# Altium schematic sheet CP2104&USB_OTG.SchDoc(CP2104&USB_OTG)
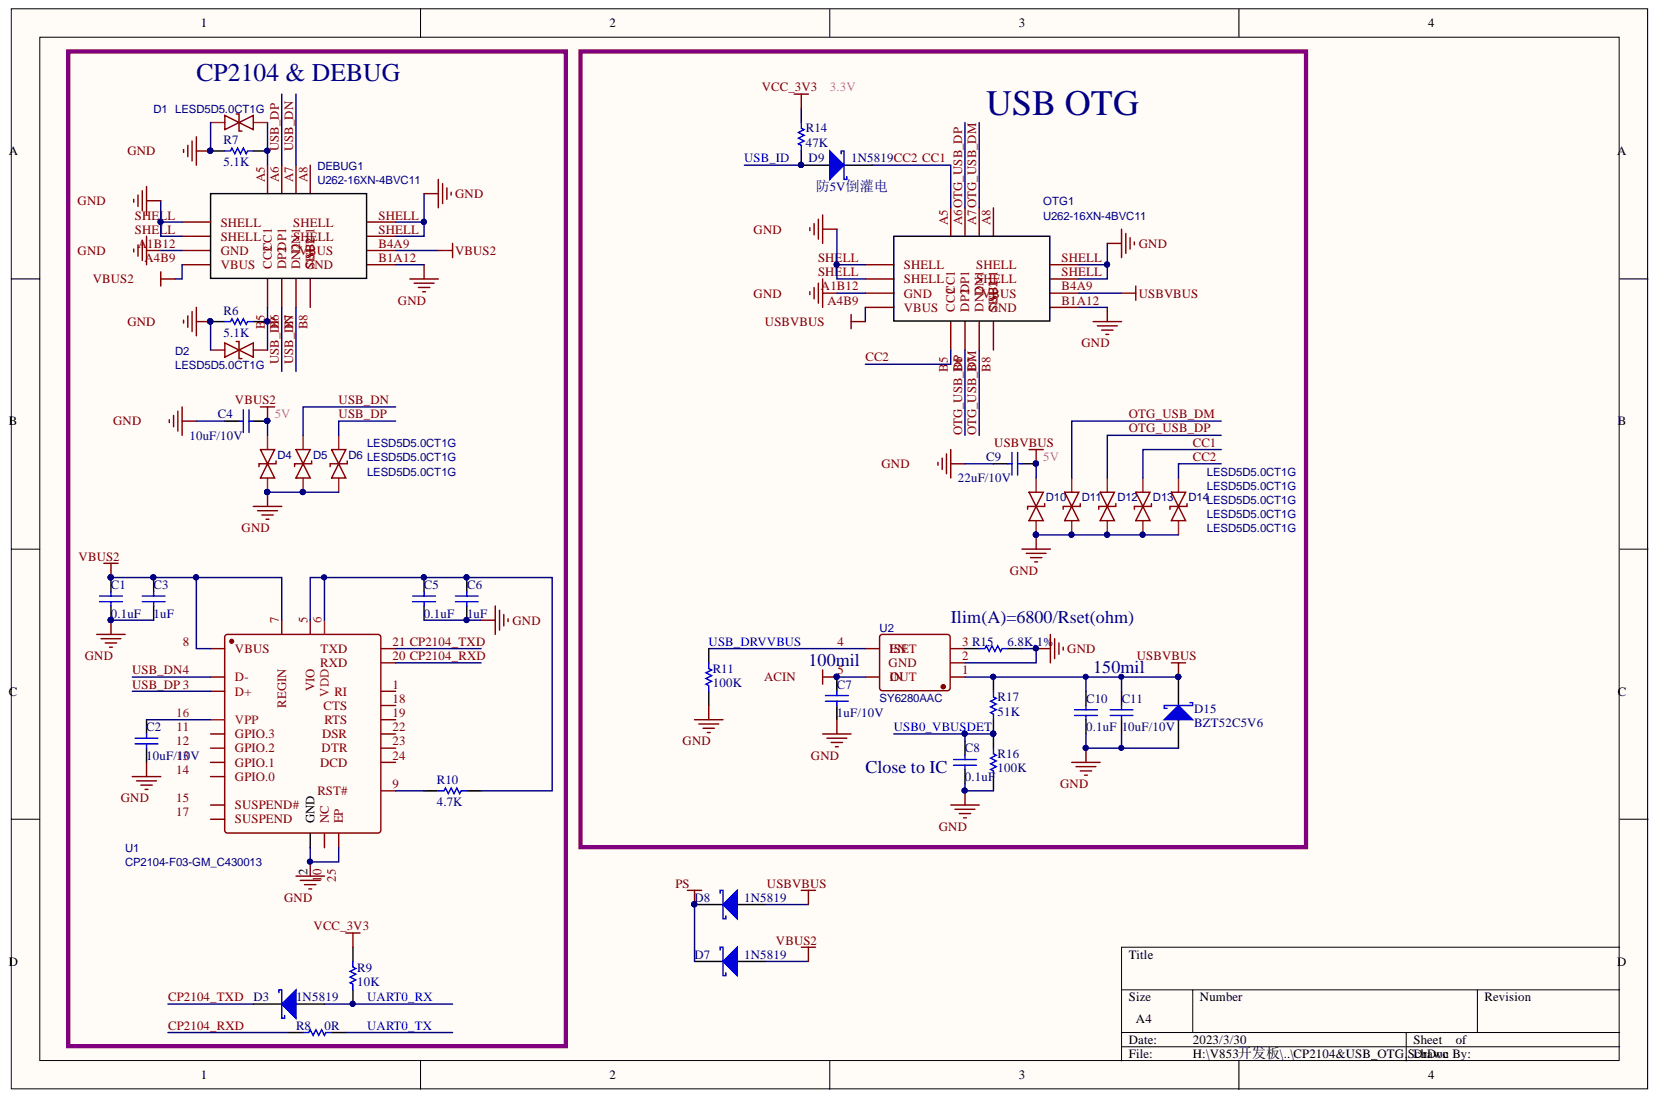
[diagram p0: CP2104&USB_OTG.SchDoc]

## Parts
C1  0.1uF  [0402]
C2  10uF/10V  [0402]
C3  1uF  [0402]
C4  10uF/10V  [0402]
C5  0.1uF  [0402]
C6  1uF  [0402]
C7  1uF/10V  [0402]
C8  0.1uF  [0402]
C9  22uF/10V  [0603]
C10  0.1uF  [0402]
C11  10uF/10V  [0402]
D1  LESD5D5.0CT1G  [SOD-523_L1.2-W0.8-LS1.6-BI]
D2  LESD5D5.0CT1G  [SOD-523_L1.2-W0.8-LS1.6-BI]
D3  1N5819  [SOD-123_L2.8-W1.8-LS3.7-RD]
D4  LESD5D5.0CT1G  [SOD-523_L1.2-W0.8-LS1.6-BI]
D5  LESD5D5.0CT1G  [SOD-523_L1.2-W0.8-LS1.6-BI]
D6  LESD5D5.0CT1G  [SOD-523_L1.2-W0.8-LS1.6-BI]
D7  1N5819  [SOD-123_L2.8-W1.8-LS3.7-RD]
D8  1N5819  [SOD-123_L2.8-W1.8-LS3.7-RD]
D9  1N5819  [SOD-123_L2.8-W1.8-LS3.7-RD]
D10  LESD5D5.0CT1G  [SOD-523_L1.2-W0.8-LS1.6-BI]
D11  LESD5D5.0CT1G  [SOD-523_L1.2-W0.8-LS1.6-BI]
D12  LESD5D5.0CT1G  [SOD-523_L1.2-W0.8-LS1.6-BI]
D13  LESD5D5.0CT1G  [SOD-523_L1.2-W0.8-LS1.6-BI]
D14  LESD5D5.0CT1G  [SOD-523_L1.2-W0.8-LS1.6-BI]
D15  BZT52C5V6  [SOD-123_L2.8-W1.8-LS3.7-RD]
DEBUG1  U262-16XN-4BVC11  [TYPEC-12PIN - duplicate]
OTG1  U262-16XN-4BVC11  [TYPEC-12PIN - duplicate]
R6  5.1K  [0402]
R7  5.1K  [0402]
R8  0R  [0402]
R9  10K  [0402]
R10  4.7K  [0402]
R11  100K  [0402]
R14  47K  [0402]
R15  6.8K,1%  [0402]
R16  100K  [0402]
R17  51K  [0402]
U1  CP2104-F03-GM_C430013  [QFN-24_L4.0-W4.0-P0.50-TL-EP2.7]
U2  SY6280AAC  [SOT-23-5_L3.0-W1.7-P0.95-LS2.8-BR]

## Nets
ACIN: C7.2 U2.5(IN)
GND: C1.2 C2.1 C3.2 C4.1 C5.2 C6.2 C7.1 C8.2 C9.1 C10.2 C11.1 D1.2 D2.2 D4.1 D5.1 D6.1 D10.1 D11.1 D12.1 D13.1 D14.1 D15.1 DEBUG1.A1B12(GND) DEBUG1.B1A12 DEBUG1.SHELL OTG1.A1B12(GND) OTG1.B1A12 OTG1.SHELL R6.2 R7.2 R11.1 R15.1 R16.1 U1.2(GND) U1.25(EP) U2.2
PS: D7.2 D8.2
USBVBUS: C9.2 C10.1 C11.2 D8.1 D10.2 D15.2 OTG1.A4B9(VBUS) OTG1.B4A9 R17.2 U2.1
VBUS2: C1.1 C3.1 C4.2 D4.2 D7.1 DEBUG1.A4B9(VBUS) DEBUG1.B4A9 U1.7 U1.8(VBUS)
VCC_3V3: R9.2 R14.2
CC1: D9.2 D13.2 D14.2 OTG1.A5 OTG1.B5(CC2)
CP2104_RXD: R8.1 U1.20
CP2104_TXD: D3.2 U1.21
OTG_USB_DM: D11.2 OTG1.A7 OTG1.B7(DN2)
OTG_USB_DP: D12.2 OTG1.A6 OTG1.B6(DP2)
UART0_RX: D3.1 R9.1
UART0_TX: R8.2
USB0_VBUSDET: C8.1 R16.2 R17.1
USB_DN: D5.2 DEBUG1.A7 DEBUG1.B7(DN2) U1.4(D-)
USB_DP: D6.2 DEBUG1.A6 DEBUG1.B6(DP2) U1.3(D+)
USB_DRVVBUS: R11.2 U2.4(ISET)
USB_ID: D9.1 R14.1
NetC2_2: C2.2 U1.16(VPP)
NetC5_1: C5.1 C6.1 R10.2 U1.5 U1.6
NetD1_1: D1.1 DEBUG1.A5 R7.1
NetD2_1: D2.1 DEBUG1.B5(CC2) R6.1
NetR10_1: R10.1 U1.9
NetR15_2: R15.2 U2.3

Not connected: DEBUG1 A8 B8(SBU2); OTG1 A8 B8(SBU2); U1 1 10(NC) 11(GPIO.3) 12(GPIO.2) 13(GPIO.1) 14(GPIO.0) 15(SUSPEND#) 17(SUSPEND) 18 19 22 23 24

## Library data of the parts
C1-C11 = Cap: Capacitor; PartNumber: CAP100NF; Component Kind: Standard; LatestRevisionDate: 17-Jul-2002; LatestRevisionNote: Re-released for DXP Platform.; Library Name: Miscellaneous Devices.IntLib; PackageReference: 0402; Published: 8-Jun-2000; Signal Integrity: Cap; Simulation: CAP; Publisher: Altium Limited
  C1 C5 C8 C10: Value: 0.1uF
  C2 C4 C11: Value: 10uF/10V
  C3 C6: Value: 1uF
  C7: Value: 1uF/10V
  C9: Value: 22uF/10V
D1 D2 D4-D6 D10-D14 = LESD5D5.0CT1G: BOM_Manufacturer: Leshan Radio; Contributor: LCSC; BOM_JLCPCB Part Class: Extended Part; BOM_Manufacturer Part: LESD5D5.0CT1G; BOM_Supplier: LCSC; BOM_Supplier Part: C383211; Component Kind: Standard; spicePre: D; spiceSymbolName: LESD5D5.0CT1G
D3 D7-D9 D15 = D Schottky: Schottky Diode; Code_JEDEC: DO-214-AA; Component Kind: Standard; LatestRevisionDate: 17-Jul-2002; LatestRevisionNote: Re-released for DXP Platform.; Library Name: Miscellaneous Devices.IntLib; PackageDescription: DO-214-AA/SMB; 2 C-Bend Leads; Body 5.3 x 3.6 mm, inc. leads (LxW); PackageReference: SMB; Published: 8-Jun-2000; Signal Integrity: D Schottky; Simulation: SKYDIODE; Publisher: Altium Limited
  D3 D7-D9: Value: 1N5819
  D15: Value: BZT52C5V6
DEBUG1 OTG1 = U262-16XN-4BVC11: BOM_Manufacturer: XKB Enterprise; Contributor: LCSC; BOM_Manufacturer Part: U262-16XN-4BVC11; BOM_Supplier: LCSC; BOM_Supplier Part: C319148; Component Kind: Standard; Library Name: HM2.SchLib; spicePre: U; spiceSymbolName: U262-16XN-4BVC11
R6-R10 R15 = Res1: Resistor; PartNumber: RES2.2K; Component Kind: Standard; LatestRevisionDate: 17-Jul-2002; LatestRevisionNote: Re-released for DXP Platform.; Library Name: Miscellaneous Devices.IntLib; PackageReference: 0402; Published: 8-Jun-2000; Signal Integrity: Res; Simulation: RESISTOR; Publisher: Altium Limited
  R6 R7: Value: 5.1K
  R8: Value: 0R
  R9: Value: 10K
  R10: Value: 4.7K
  R15: Value: 6.8K,1%
R11 R14 R16 R17 = Res1: Resistor; PartNumber: RES22R; Component Kind: Standard; LatestRevisionDate: 17-Jul-2002; LatestRevisionNote: Re-released for DXP Platform.; Library Name: Miscellaneous Devices.IntLib; PackageReference: 0402; Published: 8-Jun-2000; Signal Integrity: Res; Simulation: RESISTOR; Publisher: Altium Limited
  R11 R16: Value: 100K
  R14: Value: 47K
  R17: Value: 51K
U1 = CP2104-F03-GM_C430013: BOM_Manufacturer: SILICON LABS; Contributor: LCSC; BOM_JLCPCB Part Class: Extended Part; BOM_Manufacturer Part: CP2104-F03-GM; BOM_Supplier: LCSC; BOM_Supplier Part: C430013; Component Kind: Standard; Library Name: HM2.SchLib; spicePre: U; spiceSymbolName: CP2104-F03-GM_C430013
U2 = SY6280AAC: BOM_Manufacturer: Silergy; BOM_JLCPCB Part Class: Extended Part; BOM_Manufacturer Part: SY6280AAC; BOM_Supplier: LCSC; BOM_Supplier Part: C55136; Component Kind: Standard; Library Name: HM2.SchLib; spicePre: U; spiceSymbolName: SY6280AAC
